# Altium schematic sheet uController.SchDoc(uController)
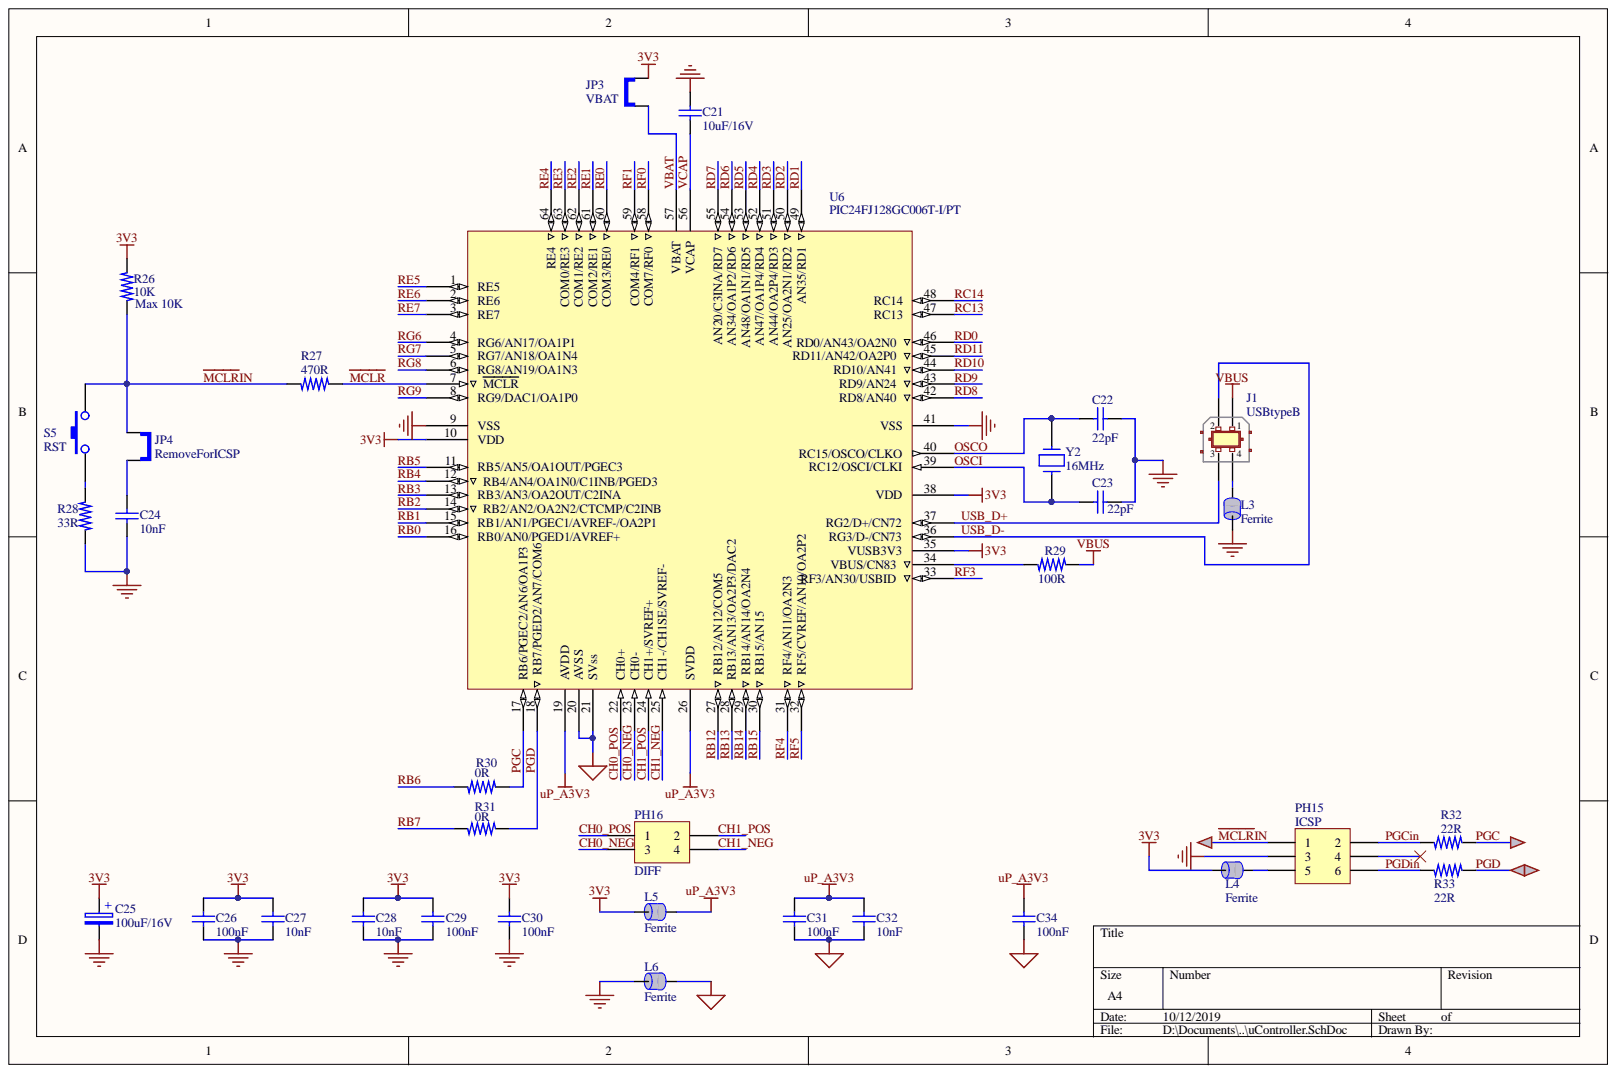
[diagram p0: uController.SchDoc]

## Parts
C21  10uF/16V  [0805Big_C]
C22  22pF  [0805Big_C]
C23  22pF  [0805Big_C]
C24  10nF  [0805_C]
C25  100uF/16V  [CAP_RAD_P04_D8x11]
C26  100nF  [0603_C]
C27  10nF  [0603_C]
C28  10nF  [0603_C]
C29  100nF  [0603_C]
C30  100nF  [0603_C]
C31  100nF  [0603_C]
C32  10nF  [0603_C]
C34  100nF  [0603_C]
J1  USBtypeB  [USB_B]
JP3  VBAT  [SIP2]
JP4  RemoveForICSP  [SIP2]
L3  Ferrite  [0805Big_R]
L4  Ferrite  [0805Big_R]
L5  Ferrite  [0805Big_R]
L6  Ferrite  [0805Big_R]
PH15  ICSP  [HDR2X3]
PH16  DIFF  [HDR2X2]
R26  10K  value Max 10K  [0805Big_R]
R27  470R  [0805Big_R]
R28  33R  [0805Big_R]
R29  100R  [0805_R]
R30  0R  [0603_R]
R31  0R  [0603_R]
R32  22R  [0805Big_R]
R33  22R  [0805Big_R]
S5  RST  [RESET2pin]
U6  PIC24FJ128GC006T-I/PT  [TQFP64PT]  (value not drawn)
Y2  16MHz  [HC-49_SMD]

## Nets
3V3: C25.1 C26.1 C27.1 C28.1 C29.1 C30.1 JP3.2 L4.1 L5.1 R26.2 U6.10(VDD) U6.35(VUSB3V3) U6.38(VDD)
GND: C21.1 C22.2 C23.2 C24.2 C25.2 C26.2 C27.2 C28.2 C29.2 C30.2 L3.1 L6.1 PH15.3 R28.1 U6.9(VSS) U6.41(VSS)
uP_A3V3: C31.1 C32.1 C34.1 L5.2 U6.19(AVDD) U6.26(SVDD)
uP_AGND: C31.2 C32.2 C34.2 L6.2 U6.20(AVSS) U6.21(SVss)
VBUS: J1.1 R29.2
CH0_NEG: PH16.3 U6.23(CH0-)
CH0_POS: PH16.1 U6.22(CH0+)
CH1_NEG: PH16.4 U6.25(CH1-/CH1SE/SVREF-)
CH1_POS: PH16.2 U6.24(CH1+/SVREF+)
~{MCLR}: R27.2 U6.7(MCLR)
~{MCLR}IN: JP4.1 PH15.1 R26.1 R27.1 S5.2
OSCI: C23.1 U6.39(RC12/OSCI/CLKI) Y2.2
OSCO: C22.1 U6.40(RC15/OSCO/CLKO) Y2.1
PGC: R30.2 R32.2 U6.17(RB6/PGEC2/AN6/OA1P3)
PGCin: PH15.2 R32.1
PGD: R31.2 R33.2 U6.18(RB7/PGED2/AN7/COM6)
PGDin: PH15.6 R33.1
RB0: U6.16(RB0/AN0/PGED1/AVREF+)
RB1: U6.15(RB1/AN1/PGEC1/AVREF-/OA2P1)
RB2: U6.14(RB2/AN2/OA2N2/CTCMP/C2INB)
RB3: U6.13(RB3/AN3/OA2OUT/C2INA)
RB4: U6.12(RB4/AN4/OA1N0/C1INB/PGED3)
RB5: U6.11(RB5/AN5/OA1OUT/PGEC3)
RB6: R30.1
RB7: R31.1
RB12: U6.27(RB12/AN12/COM5)
RB13: U6.28(RB13/AN13/OA2P3/DAC2)
RB14: U6.29(RB14/AN14/OA2N4)
RB15: U6.30(RB15/AN15)
RC13: U6.47(RC13)
RC14: U6.48(RC14)
RD0: U6.46(RD0/AN43/OA2N0)
RD1: U6.49(AN35/RD1)
RD2: U6.50(AN25/OA2N1/RD2)
RD3: U6.51(AN44/OA2P4/RD3)
RD4: U6.52(AN47/OA1P4/RD4)
RD5: U6.53(AN48/OA1N1/RD5)
RD6: U6.54(AN34/OA1P2/RD6)
RD7: U6.55(AN20/C3INA/RD7)
RD8: U6.42(RD8/AN40)
RD9: U6.43(RD9/AN24)
RD10: U6.44(RD10/AN41)
RD11: U6.45(RD11/AN42/OA2P0)
RE0: U6.60(COM3/RE0)
RE1: U6.61(COM2/RE1)
RE2: U6.62(COM1/RE2)
RE3: U6.63(COM0/RE3)
RE4: U6.64(RE4)
RE5: U6.1(RE5)
RE6: U6.2(RE6)
RE7: U6.3(RE7)
RF0: U6.58(COM7/RF0)
RF1: U6.59(COM4/RF1)
RF3: U6.33(RF3/AN30/USBID)
RF4: U6.31(RF4/AN11/OA2N3)
RF5: U6.32(RF5/CVREF/AN10/OA2P2)
RG6: U6.4(RG6/AN17/OA1P1)
RG7: U6.5(RG7/AN18/OA1N4)
RG8: U6.6(RG8/AN19/OA1N3)
RG9: U6.8(RG9/DAC1/OA1P0)
USB_D+: J1.3 U6.37(RG2/D+/CN72)
USB_D-: J1.2 U6.36(RG3/D-/CN73)
VBAT: JP3.1 U6.57(VBAT)
VCAP: C21.2 U6.56(VCAP)
NetC24_1: C24.1 JP4.2
NetJ1_4: J1.4 L3.2
NetL4_2: L4.2 PH15.5
NetR28_2: R28.2 S5.1
NetR29_1: R29.1 U6.34(VBUS/CN83)

Not connected: PH15 4

## Library data of the parts
C21-C24 C26-C32 C34 = CapCerSMD: Capacitor Ceramic SMD; Component Kind: Standard
  C21-C24: Simulation: Model Name; Library Name: MyLibrary.IntLib
  C26-C32 C34: Library Name: Passive.SchLib
C25 = CapElectr: Capacitor Electrolytic; Library Name: Passive.SchLib; Component Kind: Standard
J1 = USBtypeB: B type USB connector; Library Name: MyComponents.SchLib; Component Kind: Standard
JP3 JP4 = JUMPER: Library Name: MyLibrary.IntLib; Description: Jumper; Component Kind: Standard
L3-L6 = FerriteBead: FERRITE CHIP 220 OHM 2000mA; Value: BLM21PG221SN1D; Simulation: Model Name; Library Name: MyLibrary.IntLib; Component Kind: Standard
PH15 = Header 3X2: Header, 3-Pin, Dual row; Library Name: Connectors.SchLib; Component Kind: Standard
PH16 = Header 2X2: Header, 2-Pin, Dual row; Library Name: MyLibrary.IntLib; Component Kind: Standard
R26-R33 = ResSMD: Resistor SMD; Simulation: Model Name; Library Name: MyLibrary.IntLib; Component Kind: Standard
S5 = Button_Start: Reset Push Button; Component Kind: Standard; Library Name: NMEAlib.IntLib
U6 = PIC24FJ128GC006T-I/PT: General Purpose 16-Bit Flash Microcontroller, 128KB Flash, 8KB SRAM, 64-Pin TQFP, Industrial Temperature; PackageReference: TQFP-PT64; PackageDescription: 64-Lead Thin Plastic Quad Flat Pack (PT) - 10x10x1mm Body, 2.00mm [TQFP]; Library Name: MyLibrary.IntLib; FirstPublished: 29-Jan-2009; DatasheetVersion: revE, Oct-2008; ComponentLink3URL: http://www.microchip.com/; ComponentLink3Description: Microchip.com Home; ComponentLink2URL: http://www.microchip.com/stellent/groups/techpub_sg/documents/packagingspec/en012702.pdf; ComponentLink2Description: Packaging Specification; ComponentLink1URL: http://ww1.microchip.com/downloads/en/DeviceDoc/39747e.pdf; ComponentLink1Description: Datasheet; Component Kind: Standard; Code_JEDEC: MS-026; Publisher: Altium Limited
Y2 = CRYSTAL: Library Name: MyComponents.SchLib; Description: Crystal; Component Kind: Standard
